# Altium schematic sheet Sheet2.SchDoc(Sheet2)
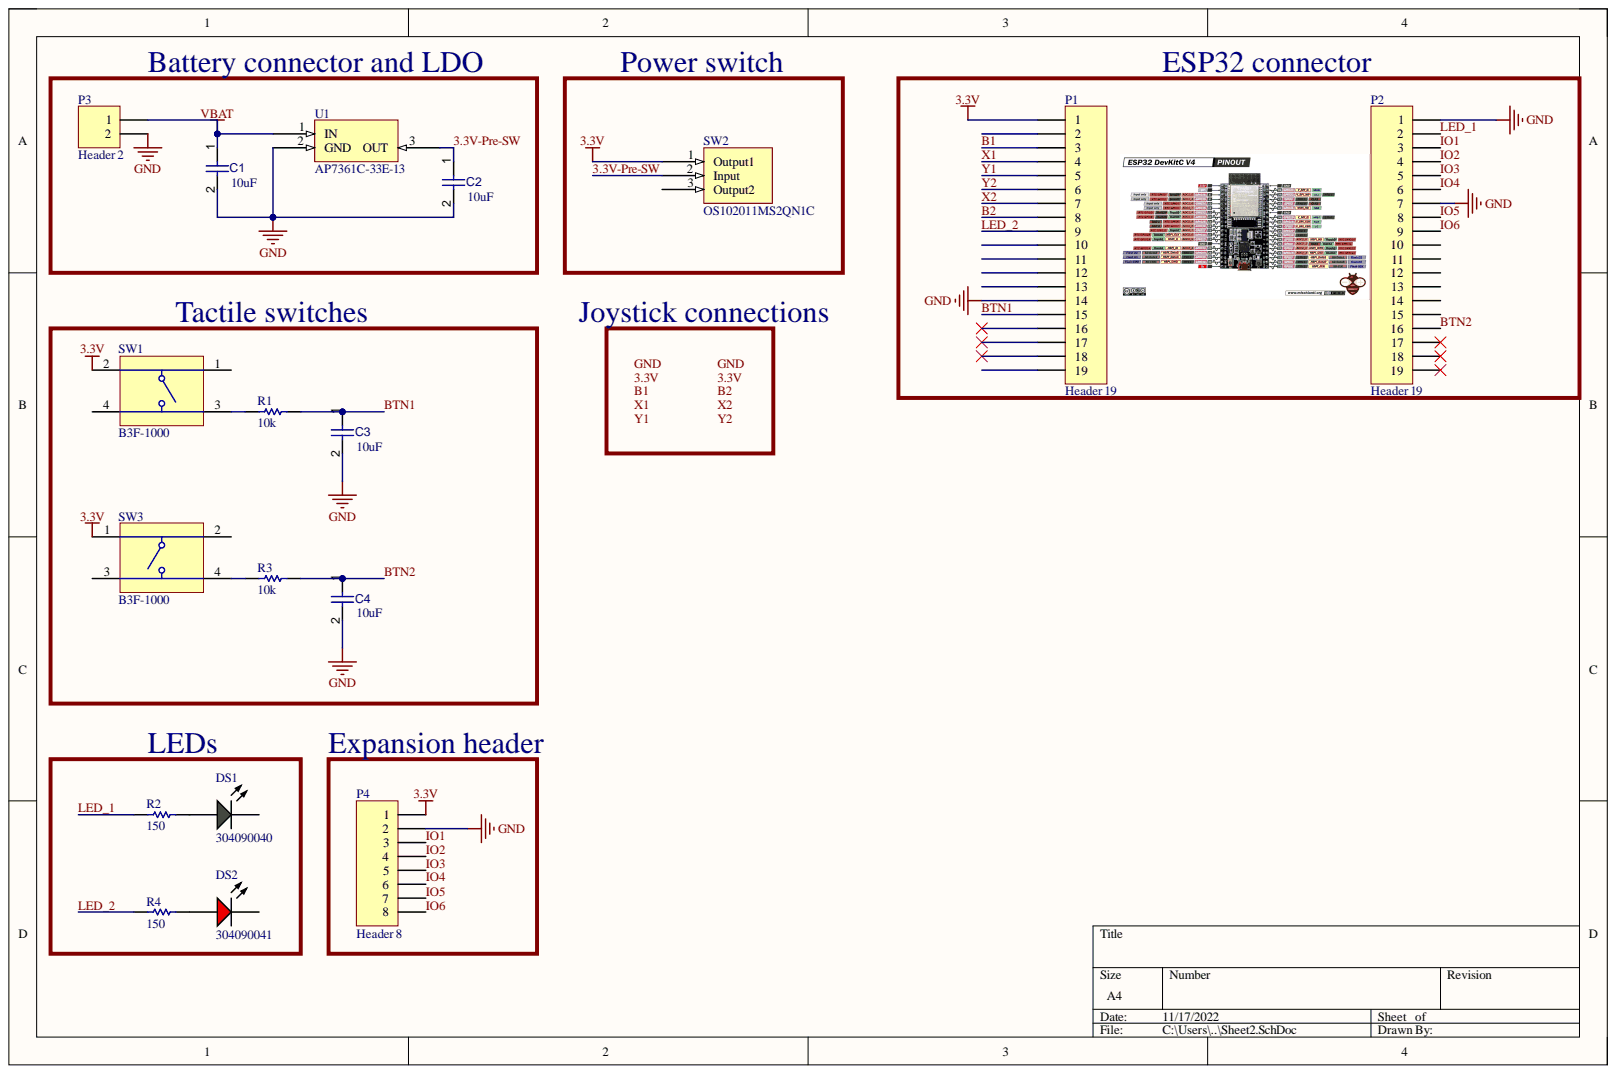
[diagram p0: Sheet2.SchDoc]

## Parts
C1  value 10uF  [CAPC2013X135X45NL10T25]  (hidden comment: Capacitor 10 uF +/- 10% 16 V 0805)
C2  value 10uF  [CAPC2013X135X45NL10T25]  (hidden comment: Capacitor 10 uF +/- 10% 16 V 0805)
C3  value 10uF  [CAPC2013X135X45NL10T25]  (hidden comment: Capacitor 10 uF +/- 10% 16 V 0805)
C4  value 10uF  [CAPC2013X135X45NL10T25]  (hidden comment: Capacitor 10 uF +/- 10% 16 V 0805)
DS1  304090040  [LED-2P-2.28-3MM]
DS2  304090041  [LED-2P-2.28-3MM_A]
P1  Header 19  [HDR1X19]
P2  Header 19  [HDR1X19]
P3  Header 2  [HDR1X2]
P4  Header 8  [HDR1X8]
R1  value 10k  [RESC2013X70X40ML20T20]  (hidden comment: Resistor 1k +/-1% 0805 125 mW)
R2  value 150  [RESC2013X70X40ML20T20]  (hidden comment: Resistor 1k +/-1% 0805 125 mW)
R3  value 10k  [RESC2013X70X40ML20T20]  (hidden comment: Resistor 1k +/-1% 0805 125 mW)
R4  value 150  [RESC2013X70X40ML20T20]  (hidden comment: Resistor 1k +/-1% 0805 125 mW)
SW1  B3F-1000  [OMRON-B3F-1000-4_V]
SW2  OS102011MS2QN1C  [OS102011MS2QN1C-Footprint-1]
SW3  B3F-1000  [OMRON-B3F-1000-4_V]
U1  AP7361C-33E-13  [SOT230P700X180-4N]

## Nets
VBAT: C1.1 P3.1 U1.1
3.3V: P1.1 P4.1 SW1.2 SW2.1 SW3.1
3.3V-Pre-SW: C2.1 SW2.2 U1.3
B1: P1.3
B2: P1.8
BTN1: C3.1 P1.15 R1.2
BTN2: C4.1 P2.16 R3.2
GND: C1.2 C2.2 C3.2 C4.2 P1.14 P2.1 P2.7 P3.2 P4.2 U1.2
IO1: P2.3 P4.3
IO2: P2.4 P4.4
IO3: P2.5 P4.5
IO4: P2.6 P4.6
IO5: P2.8 P4.7
IO6: P2.9 P4.8
LED_1: P2.2 R2.1
LED_2: P1.9 R4.1
X1: P1.4
X2: P1.7
Y1: P1.5
Y2: P1.6
NetDS1_1: DS1.1 R2.2
NetDS2_1: DS2.1 R4.2
NetR1_1: R1.1 SW1.3
NetR3_1: R3.1 SW3.4

Not connected: DS1 2; DS2 2; P1 2 10 11 12 13 16 17 18 19; P2 10 11 12 13 14 15 17 18 19; SW1 1 4; SW2 3; SW3 2 3

## Library data of the parts
C1-C4 = CMP-001-00015-6: Chip Capacitor, 10 uF, +/- 10%, 16 V, 0805 (2012 Metric); Capacitor Type: Ceramic; Voltage Rating: 16V; Case/Package: 0805; Component Kind: Standard; Max Operating Temperature: 85°C; Min Operating Temperature: -55°C; Mounting Technology: SM; Pins: 2; RoHS Compliant: True; Tolerance: 10%; Library Name: Copenhagen School of Design and Technology (KEA)
DS1 = CMP-007-00006-2: Green LED, 80 mW, 20 mA, -25 to 85 degC, 2-Pin THD; Manufacturer: Shenzhen CGX; Case/Package: 304090040; Component Kind: Standard; Datasheet URL: http://datasheet.octopart.com/304090040-Chengguangxing-datasheet-62330655.pdf; Datasheet Version: Rev. 1.0, 09/2014; Manufacturer URL: http://szcgx.com/; Mounting Technology: TH; Package Description: 2-Pin Through Hole Device, Dia 3 mm, Pitch 2.28 mm; Package Reference: 304090040; RoHS Compliant: Unknown; Library Name: Erhvervsakademierne Danmark
DS2 = CMP-007-00007-2: Red L2ED, 80 mW, 30 mA, -25 to 85 degC, 2-Pin THD; Manufacturer: Shenzhen CGX; Case/Package: 304090041; Component Kind: Standard; Datasheet URL: http://datasheet.octopart.com/304090041-Chengguangxing-datasheet-62330656.pdf; Datasheet Version: Sep-14; Manufacturer URL: http://szcgx.com/; Mounting Technology: TH; Package Description: 2-Pin Through Hole Device, Dia 3 mm, Pitch 2.28 mm; Package Reference: 304090041; RoHS Compliant: Unknown; Library Name: Erhvervsakademierne Danmark
P1 P2 = Header 19: Header, 19-Pin; Component Kind: Standard; LatestRevisionDate: 17-Jul-2002; LatestRevisionNote: Re-released for DXP Platform.; Library Name: Miscellaneous Connectors.IntLib; Signal Integrity: Connector; Publisher: Altium Limited
P3 = Header 2: Header, 2-Pin; Component Kind: Standard; LatestRevisionDate: 17-Jul-2002; LatestRevisionNote: Re-released for DXP Platform.; Library Name: Miscellaneous Connectors.IntLib; Signal Integrity: Connector; Publisher: Altium Limited
P4 = Header 8: Header, 8-Pin; Component Kind: Standard; LatestRevisionDate: 17-Jul-2002; LatestRevisionNote: Re-released for DXP Platform.; Library Name: Miscellaneous Connectors.IntLib; Signal Integrity: Connector; Publisher: Altium Limited
R1-R4 = CMP-009-00010-5: Chip Resistor, 1 KOhm, +/- 1%, 0.125 W, -55 to 155 degC, 0805 (2013 Metric); Power: 125mW; Voltage Rating: 150V; Case/Package: 0805; Component Kind: Standard; Max Operating Temperature: 155°C; Min Operating Temperature: -55°C; Mounting Technology: SM; Pins: 2; RoHS Compliant: True; Tolerance: 1%; Library Name: Erhvervsakademierne Danmark
SW1 SW3 = CMP-011-00004-2: Tactile Switch, SPST-NO, 0.05 A, 24 V, -25 to 70 degC, 4-Pin THD, RoHS, Bulk; Manufacturer: Omron Electronic Components; Contact Current Rating: 50mA; Max Contact Resistance: 100mohm; Max Voltage Rating (DC): 24V; Operating Force: 100gf; Resistance: 0.1Ohm; Throw Configuration: SPST; Case/Package: B3F-1000; Component Kind: Standard; Contact Material: Gold; Contact Plating: Gold; Current Rating: 50mA; Datasheet URL: http://www.omron.com/ecb/products/pdf/en-b3f.pdf; Datasheet Version: Jan-15; Manufacturer URL: http://www.omron.com/; Max Current Rating: 50mA; Max Operating Temperature: 70°C; Min Operating Temperature: -25°C; Mounting Technology: TH; Package Description: 4-Pin Through Hole Device, Body 6 x 6 mm; Package Quantity: 1500; Package Reference: B3F-1000; Packaging: Bulk; Pins: 4; RoHS Compliant: True; Voltage Rating: 24V; Voltage Rating (DC): 24V; Library Name: Erhvervsakademierne Danmark
SW2 = CMP-011-00013-2: Switch Slide ON ON SPDT Top Slide 0.1A 12VDC 10000Cycles PC Pins Bracket Mount/Through Hole Bulk; Component Kind: Standard; Library Name: Erhvervsakademierne Danmark
U1 = CMP-019-00049-1: LDO Voltage Regulators 1A LDO Reg w/oEnable 3.3V 6.5Vin 1100mW; Component Kind: Standard; Library Name: Copenhagen School of Design and Technology (KEA)
